# Altium schematic sheet MCU.SchDoc
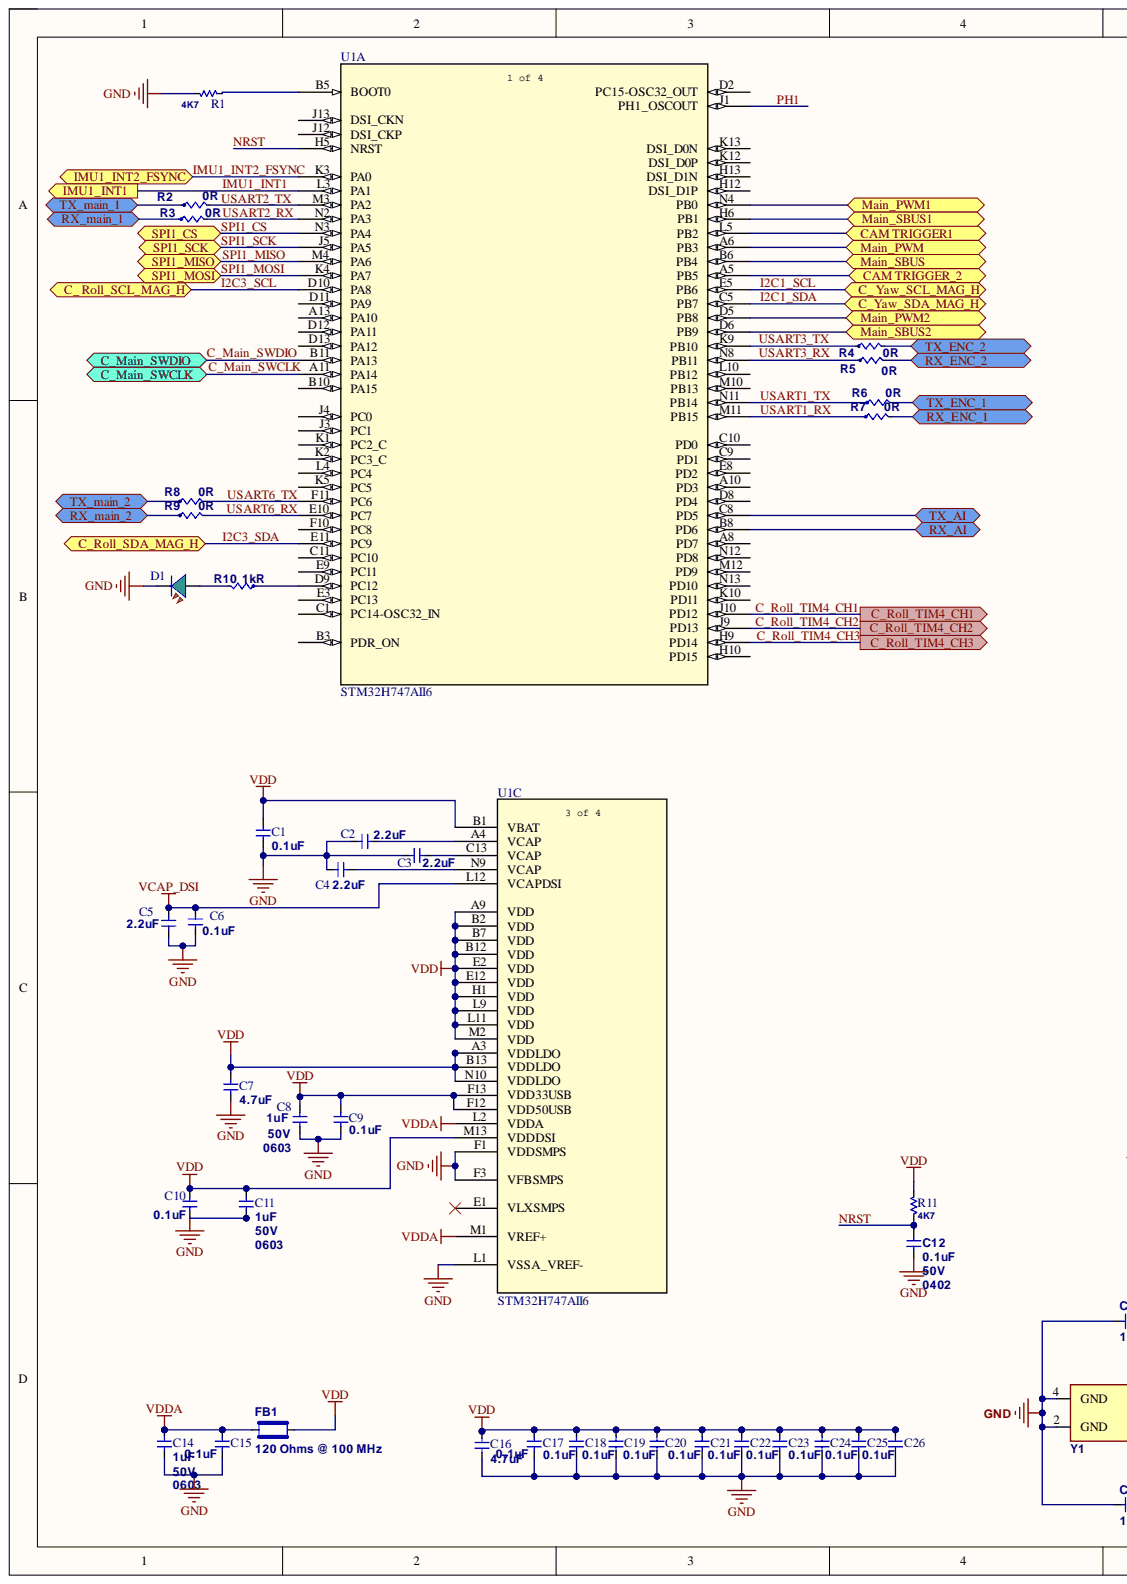
[diagram p0: MCU.SchDoc, left]
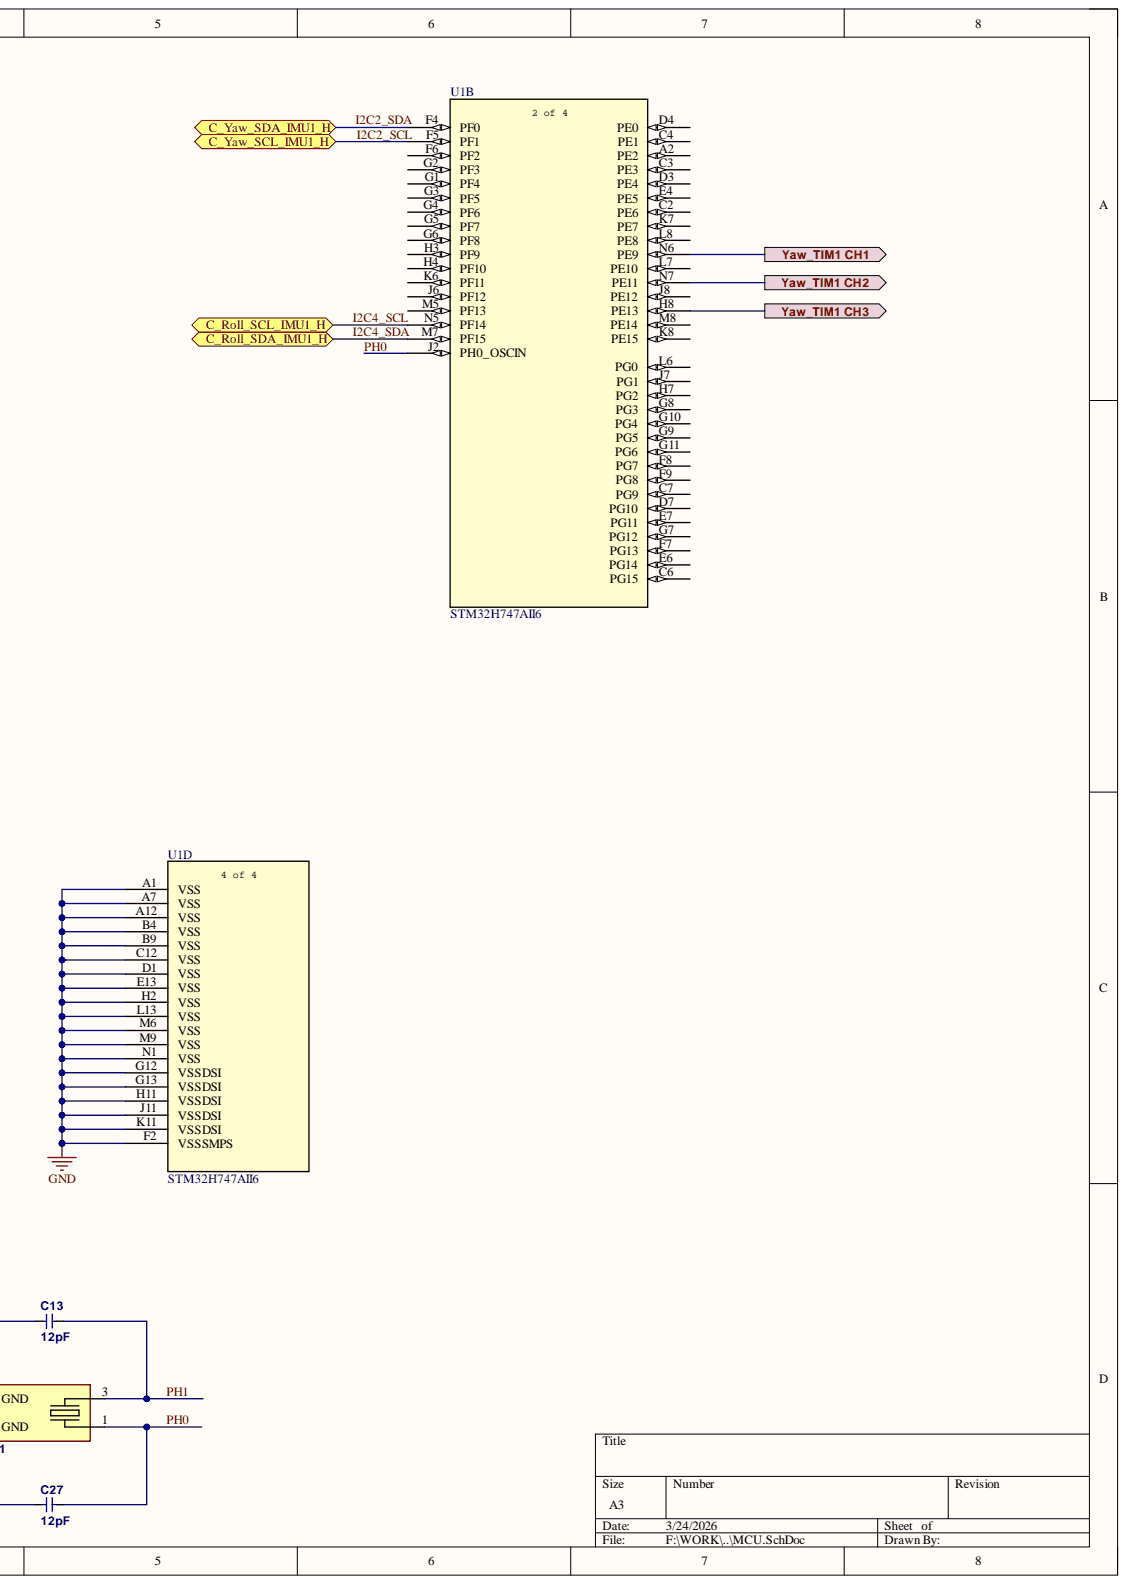
[diagram p1: MCU.SchDoc, right]

## Parts
C1  value 0.1uF  [CAP0603]  (hidden comment: CAP0603 0.1uF 50V)
C2  value 2.2uF  [CAP0603]  (hidden comment: CAP0603 2.2uF 25V)
C3  value 2.2uF  [CAP0603]  (hidden comment: CAP0603 2.2uF 25V)
C4  value 2.2uF  [CAP0603]  (hidden comment: CAP0603 2.2uF 25V)
C5  value 2.2uF  [CAP0603]  (hidden comment: CAP0603 2.2uF 25V)
C6  value 0.1uF  [CAP0603]  (hidden comment: CAP0603 0.1uF 50V)
C7  value 4.7uF  [CAP0603]  (hidden comment: CAP0603 4.7uF 10V)
C8  value 1uF  Package: 0603  Voltage - Rated: 50V  [CAP0603]  (hidden comment: CAP0603 1uF 50V)
C9  value 0.1uF  [CAP0603]  (hidden comment: CAP0603 0.1uF 50V)
C10  value 0.1uF  [CAP0603]  (hidden comment: CAP0603 0.1uF 50V)
C11  value 1uF  Package: 0603  Voltage - Rated: 50V  [CAP0603]  (hidden comment: CAP0603 1uF 50V)
C12  value 0.1uF  Package: 0402  Voltage: 50V  [CAP0402]  (hidden comment: CAP0402 0.1uF 50V)
C13  value 12pF  [CAP0402]  (hidden comment: CAP0402 12pF 50V)
C14  value 1uF  Package: 0603  Voltage - Rated: 50V  [CAP0603]  (hidden comment: CAP0603 1uF 50V)
C15  value 0.1uF  [CAP0603]  (hidden comment: CAP0603 0.1uF 50V)
C16  value 4.7uF  [CAP0603]  (hidden comment: CAP0603 4.7uF 10V)
C17  value 0.1uF  [CAP0603]  (hidden comment: CAP0603 0.1uF 50V)
C18  value 0.1uF  [CAP0603]  (hidden comment: CAP0603 0.1uF 50V)
C19  value 0.1uF  [CAP0603]  (hidden comment: CAP0603 0.1uF 50V)
C20  value 0.1uF  [CAP0603]  (hidden comment: CAP0603 0.1uF 50V)
C21  value 0.1uF  [CAP0603]  (hidden comment: CAP0603 0.1uF 50V)
C22  value 0.1uF  [CAP0603]  (hidden comment: CAP0603 0.1uF 50V)
C23  value 0.1uF  [CAP0603]  (hidden comment: CAP0603 0.1uF 50V)
C24  value 0.1uF  [CAP0603]  (hidden comment: CAP0603 0.1uF 50V)
C25  value 0.1uF  [CAP0603]  (hidden comment: CAP0603 0.1uF 50V)
C26  value 0.1uF  [CAP0603]  (hidden comment: CAP0603 0.1uF 50V)
C27  value 12pF  [CAP0402]  (hidden comment: CAP0402 12pF 50V)
D1  SML-310MTT86  [SML-310MTT86-Footprint-1]  (value not drawn)
FB1  BLM15PX121SZ1D  [FERRIT0402]  (value not drawn)
R1  value 4K7  [RES0402]  (hidden comment: RES0402 4K7)
R2  Resistance: 0R  [RES0402]  (hidden comment: ERJ-2RKF4701X)
R3  Resistance: 0R  [RES0402]  (hidden comment: ERJ-2RKF4701X)
R4  Resistance: 0R  [RES0402]  (hidden comment: ERJ-2RKF4701X)
R5  Resistance: 0R  [RES0402]  (hidden comment: ERJ-2RKF4701X)
R6  Resistance: 0R  [RES0402]  (hidden comment: ERJ-2RKF4701X)
R7  Resistance: 0R  [RES0402]  (hidden comment: ERJ-2RKF4701X)
R8  Resistance: 0R  [RES0402]  (hidden comment: ERJ-2RKF4701X)
R9  Resistance: 0R  [RES0402]  (hidden comment: ERJ-2RKF4701X)
R10  Resistance: 1kR  [RES0603]  (hidden comment: RC0603FR-071KL)
R11  value 4K7  [RES0402]  (hidden comment: RES0402 4K7)
U1  STM32H747AII6  [UFBGA169]  (parts A,B,C,D)
Y1  ECS-240-12-37B-CKY-TR  [XTAL_ECS-240-12-37B-CKY-TR]  (value not drawn)

## Ports
C_ Roll_SCL_MAG_H, C_ Yaw_SCL_MAG_H, C_Main_SWCLK, C_Main_SWDIO, C_Roll_SCL_IMU1_H, C_Roll_SDA_IMU1_H, C_Roll_SDA_MAG_H, C_Roll_TIM4_CH1, C_Roll_TIM4_CH2, C_Roll_TIM4_CH3, C_Yaw_SCL_IMU1_H, C_Yaw_SDA_IMU1_H, C_Yaw_SDA_MAG_H, CAM TRIGGER1, CAM TRIGGER_2, IMU1_INT1, IMU1_INT2_FSYNC, Main_PWM, Main_PWM1, Main_PWM2, Main_SBUS, Main_SBUS1, Main_SBUS2, RX_AI, RX_ENC_1, RX_ENC_2, RX_main_1, RX_main_2, SPI1_CS, SPI1_MISO, SPI1_MOSI, SPI1_SCK, TX_AI, TX_ENC_1, TX_ENC_2, TX_main_1, TX_main_2, Yaw_TIM1 CH1, Yaw_TIM1 CH2, Yaw_TIM1 CH3

## Nets
GND: C1.1 C5.1 C6.1 C7.1 C8.1 C9.1 C12.1 C13.2 C14.1 C15.1 C16.1 C17.1 C18.1 C19.1 C20.1 C21.1 C22.1 C23.1 C24.1 C25.1 C26.1 C27.2 D1.1 R1.1 U1.A1(VSS) U1.A7(VSS) U1.A12(VSS) U1.B4(VSS) U1.B9(VSS) U1.C12(VSS) U1.D1(VSS) U1.E13(VSS) U1.F1(VDDSMPS) U1.F2(VSSSMPS) U1.F3(VFBSMPS) U1.G12(VSSDSI) U1.G13(VSSDSI) U1.H2(VSS) U1.H11(VSSDSI) U1.J11(VSSDSI) U1.K11(VSSDSI) U1.L1(VSSA_VREF-) U1.L13(VSS) U1.M6(VSS) U1.M9(VSS) U1.N1(VSS) Y1.2(GND) Y1.4(GND)
VCAP_DSI: C5.2 C6.2 U1.L12(VCAPDSI)
VDD: C1.2 C7.2 C8.2 C9.2 C10.2 C11.2 C16.2 C17.2 C18.2 C19.2 C20.2 C21.2 C22.2 C23.2 C24.2 C25.2 C26.2 FB1.2 R11.2 U1.A3(VDDLDO) U1.A9(VDD) U1.B1(VBAT) U1.B2(VDD) U1.B7(VDD) U1.B12(VDD) U1.B13(VDDLDO) U1.E2(VDD) U1.E12(VDD) U1.F12(VDD50USB) U1.F13(VDD33USB) U1.H1(VDD) U1.L9(VDD) U1.L11(VDD) U1.M2(VDD) U1.M13(VDDDSI) U1.N10(VDDLDO)
VDDA: C14.2 C15.2 FB1.1 U1.L2(VDDA) U1.M1(VREF+)
C_Main_SWCLK: U1.A11(PA14) ; port C_Main_SWCLK
C_Main_SWDIO: U1.B11(PA13) ; port C_Main_SWDIO
C_Roll_TIM4_CH1: U1.J10(PD12) ; port C_Roll_TIM4_CH1
C_Roll_TIM4_CH2: U1.J9(PD13) ; port C_Roll_TIM4_CH2
C_Roll_TIM4_CH3: U1.H9(PD14) ; port C_Roll_TIM4_CH3
CAM TRIGGER1: U1.L5(PB2) ; port CAM TRIGGER1
CAM TRIGGER_2: U1.A5(PB5) ; port CAM TRIGGER_2
I2C1_SCL: U1.E5(PB6) ; port C_ Yaw_SCL_MAG_H
I2C1_SDA: U1.C5(PB7) ; port C_Yaw_SDA_MAG_H
I2C2_SCL: U1.F5(PF1) ; port C_Yaw_SCL_IMU1_H
I2C2_SDA: U1.F4(PF0) ; port C_Yaw_SDA_IMU1_H
I2C3_SCL: U1.D10(PA8) ; port C_ Roll_SCL_MAG_H
I2C3_SDA: U1.E11(PC9) ; port C_Roll_SDA_MAG_H
I2C4_SCL: U1.N5(PF14) ; port C_Roll_SCL_IMU1_H
I2C4_SDA: U1.M7(PF15) ; port C_Roll_SDA_IMU1_H
IMU1_INT1: U1.L3(PA1) ; port IMU1_INT1
IMU1_INT2_FSYNC: U1.K3(PA0) ; port IMU1_INT2_FSYNC
Main_PWM: U1.A6(PB3) ; port Main_PWM
Main_PWM1: U1.N4(PB0) ; port Main_PWM1
Main_PWM2: U1.D5(PB8) ; port Main_PWM2
Main_SBUS: U1.B6(PB4) ; port Main_SBUS
Main_SBUS1: U1.H6(PB1) ; port Main_SBUS1
Main_SBUS2: U1.D6(PB9) ; port Main_SBUS2
NRST: C12.2 R11.1 U1.H5(NRST)
PH0: C27.1 U1.J2(PH0_OSCIN) Y1.1
PH1: C13.1 U1.J1(PH1_OSCOUT) Y1.3
RX_AI: U1.B8(PD6) ; port RX_AI
RX_ENC_1: R7.2 ; port RX_ENC_1
RX_ENC_2: R5.2 ; port RX_ENC_2
RX_main_1: R3.1 ; port RX_main_1
RX_main_2: R9.1 ; port RX_main_2
SPI1_CS: U1.N3(PA4) ; port SPI1_CS
SPI1_MISO: U1.M4(PA6) ; port SPI1_MISO
SPI1_MOSI: U1.K4(PA7) ; port SPI1_MOSI
SPI1_SCK: U1.J5(PA5) ; port SPI1_SCK
TX_AI: U1.C8(PD5) ; port TX_AI
TX_ENC_1: R6.2 ; port TX_ENC_1
TX_ENC_2: R4.2 ; port TX_ENC_2
TX_main_1: R2.1 ; port TX_main_1
TX_main_2: R8.1 ; port TX_main_2
USART1_RX: R7.1 U1.M11(PB15)
USART1_TX: R6.1 U1.N11(PB14)
USART2_RX: R3.2 U1.N2(PA3)
USART2_TX: R2.2 U1.M3(PA2)
USART3_RX: R5.1 U1.N8(PB11)
USART3_TX: R4.1 U1.K9(PB10)
USART6_RX: R9.2 U1.E10(PC7)
USART6_TX: R8.2 U1.F11(PC6)
Yaw_TIM1 CH1: U1.N6(PE9) ; port Yaw_TIM1 CH1
Yaw_TIM1 CH2: U1.N7(PE11) ; port Yaw_TIM1 CH2
Yaw_TIM1 CH3: U1.H8(PE13) ; port Yaw_TIM1 CH3
NetC2_1: C2.1 U1.A4(VCAP)
NetC2_2: C2.2 C3.2 C4.2
NetC3_1: C3.1 U1.C13(VCAP)
NetC4_1: C4.1 U1.N9(VCAP)
NetC10_1: C10.1 C11.1
NetD1_2: D1.2 R10.2
NetR1_2: R1.2 U1.B5(BOOT0)
NetR10_1: R10.1 U1.D9(PC12)

Not connected: U1 A2(PE2) A8(PD7) A10(PD3) A13(PA10) B3(PDR_ON) B10(PA15) C1(PC14-OSC32_IN) C2(PE6) C3(PE3) C4(PE1) C6(PG15) C7(PG9) C9(PD1) C10(PD0) C11(PC10) D2(PC15-OSC32_OUT) D3(PE4) D4(PE0) D7(PG10) D8(PD4) D11(PA9) D12(PA11) D13(PA12) E1(VLXSMPS) E3(PC13) E4(PE5) E6(PG14) E7(PG11) E8(PD2) E9(PC11) F6(PF2) F7(PG13) F8(PG7) F9(PG8) F10(PC8) G1(PF4) G2(PF3) G3(PF5) G4(PF6) G5(PF7) G6(PF8) G7(PG12) G8(PG3) G9(PG5) G10(PG4) G11(PG6) H3(PF9) H4(PF10) H7(PG2) H10(PD15) H12(DSI_D1P) H13(DSI_D1N) J3(PC1) J4(PC0) J6(PF12) J7(PG1) J8(PE12) J12(DSI_CKP) J13(DSI_CKN) K1(PC2_C) K2(PC3_C) K5(PC5) K6(PF11) K7(PE7) K8(PE15) K10(PD11) K12(DSI_D0P) K13(DSI_D0N) L4(PC4) L6(PG0) L7(PE10) L8(PE8) L10(PB12) M5(PF13) M8(PE14) M10(PB13) M12(PD9) N12(PD8) N13(PD10)

## Library data of the parts
C1 C6 C9 C10 C15 C17-C26 = CAP0603 0.1uF 50V: Cap 0.1uF 50V 0603; MP: C0603C104M5RAC7867; Component Kind: Standard; Package: 0603; Voltage: 50V; Library Name: RTR.SchLib
C2-C5 = CAP0603 2.2uF 25V: Cap 2.2uF 25V 0603; MP: GRM188R61E225KA12DGRM188R61E225KA12D; Component Kind: Standard; Library Name: RTR.SchLib
C7 C16 = CAP0603 4.7uF 10V: Cap 4.7uF 10V 0603; MP: CC0603KRX5R6BB475; Component Kind: Standard; Library Name: RTR.SchLib
C8 C11 C14 = CAP0603 1uF 50V: Cap 1uF 50V 0603; MP: C1608X5R1H105K080AB; Tolerance: ±10%; Component Kind: Standard; Library Name: RTR.SchLib
C12 = CAP0402 0.1uF 50V: Cap 0.1uF 50V 0402; MP: CC0402KRX5R9BB104; Component Kind: Standard; Library Name: RTR.SchLib
C13 C27 = CAP0402 12pF 50V: Cap 12pF 50V 0402; Component Kind: Standard; Library Name: RTR.SchLib
D1 = CMP-01130-2: LED GREEN CLEAR 0603 SMD; Manufacturer 1: Rohm Semiconductor; Color: Green,Yellow; Dominant Wavelength: 570nm; Forward Current: 20mA; Forward Voltage: 2.2V; Lens Color: Colorless,Clear; Lens Style: Rectangular,Clear,Diffused,Colorless; Luminous Intensity: 16mcd; Number of LEDs: 1; Viewing Angle: 140°; Case Code (Imperial): 0603; Case Code (Metric): 1608; Case/Package: 0603; Component Kind: Standard; Height: 0.9mm; Illumination Color: Green; Lead Free: Lead Free; Length: 1.6mm; Lens Transparency: Clear; Manufacturer Part Number 1: SML-310MTT86; Max Operating Temperature: 85°C; Min Operating Temperature: -30°C; Mount: Surface Mount; Number of Pins: 2; Package Quantity: 3000; Packaging: Tape and Reel; Radiation Hardening: No; Test Current: 20mA; Wavelength: 570nm; Width: 0.8mm; Library Name: HeraJetson_Modified.IntLib
FB1 = BLM15PX121SZ1D: 120 Ohms @ 100 MHz 1 Power Line Ferrite Bead 0402 (1005 Metric) 2A 55mOhm; Component Kind: Standard; Current Rating (Max): 2A; DC Resistance (DCR) (Max): 55mOhm; Impedance @ Frequency: 120 Ohms @ 100 MHz; MP: BLM15PX121SZ1D; Library Name: RTR.SchLib
R1 R11 = RES0402 4K7: RES 4K7 0402; MP: RC0402JR-074K7L; Component Kind: Standard; Library Name: RTR.SchLib
R2-R9 = CMP-00607-1: RES SMD 4.7K OHM 1% 1/10W 0402; Manufacturer 1: Panasonic Electronic Components; Max Power Dissipation: 100mW; Temperature Coefficient: 100ppm/°C; Voltage Rating: 50V; Case Code (Imperial): 0402; Case Code (Metric): 1005; Case/Package: 0402; Component Kind: Standard; Composition: Thick Film; Contact Plating: Tin; Depth: 0.5mm; Features: Automotive AEC-Q200; Height: 0.35mm; Lead Free: Lead Free; Length: 1mm; Manufacturer Part Number 1: ERJ-2RKF4701X; Max Operating Temperature: 155°C; Military Standard: Not; Min Operating Temperature: -55°C; Mount: Surface Mount; Number of Pins: 2; Number of Terminations: 2; Package Quantity: 1; Packaging: Tape and Reel; Power Rating: 100mW; Radiation Hardening: No; REACH SVHC: No SVHC; Termination: SMD/SMT; Tolerance: 1%; Voltage Rating (DC): 50V; Width: 0.02inch; Library Name: rtr.IntLib
R10 = CMP-00067-1: RES SMD 1K OHM 1% 1/10W 0603; Manufacturer: Yageo; Power Rating: 100mW; Temperature Coefficient: 100ppm/°C; Voltage Rating: 50V; Case Code (Imperial): 0603; Case Code (Metric): 1608; Case/Package: 0603; Component Kind: Standard; Composition: Thick Film; Contact Plating: Tin,Matte; Depth: 0.8mm; Height: 0.45mm; Lead Free: Lead Free; Length: 1.6mm; Manufacturer 1: Yageo; Manufacturer Part Number: RC0603FR-071KL; Manufacturer Part Number 1: RC0603FR-071KL; Max Operating Temperature: 155°C; Military Standard: Not; Min Operating Temperature: -55°C; Packaging: Tape and Reel; Radiation Hardening: No; REACH SVHC: No SVHC; Termination: SMD/SMT; Tolerance: 1%; Library Name: rtr.IntLib
U1 = STM32H747AII6: High-performance and DSP with DP-FPU, Arm Cortex-M7 + Cortex-M4 MCU with 2MBytes of Flash memory, 1MB RAM, 480 MHz CPU, Art Accelerator, L1 cache, external memory interface, large set of peripherals, SMPS, MIPI-DSI; Manufacturer_Name: STMicroelectronics; Component Kind: Standard; Manufacturer_Part_Number: STM32H747AII6; RefDes: RefDes; Type: Type; Copyright: Copyright (C) 2026 Ultra Librarian. All rights reserved.; Library Name: RTR.SchLib
Y1 = ECS-240-12-37B-CKY-TR: Crystal 24 MHz ±10ppm 12pF 50 Ohms 4-SMD, No Lead; ESR (Equivalent Series Resistance): 50 Ohms; Frequency: 24 MHz; Component Kind: Standard; Frequency Stability: ±10ppm; Frequency Tolerance: ±10ppm; Load Capacitance: 12pF; MP: ECS-240-12-37B-CKY-TR; Operating Temperature: -30°C ~ 85°C; Size / Dimension: 2.00mm x 1.60mm; Library Name: RTR.SchLib
